# Altium schematic sheet IO.SchDoc
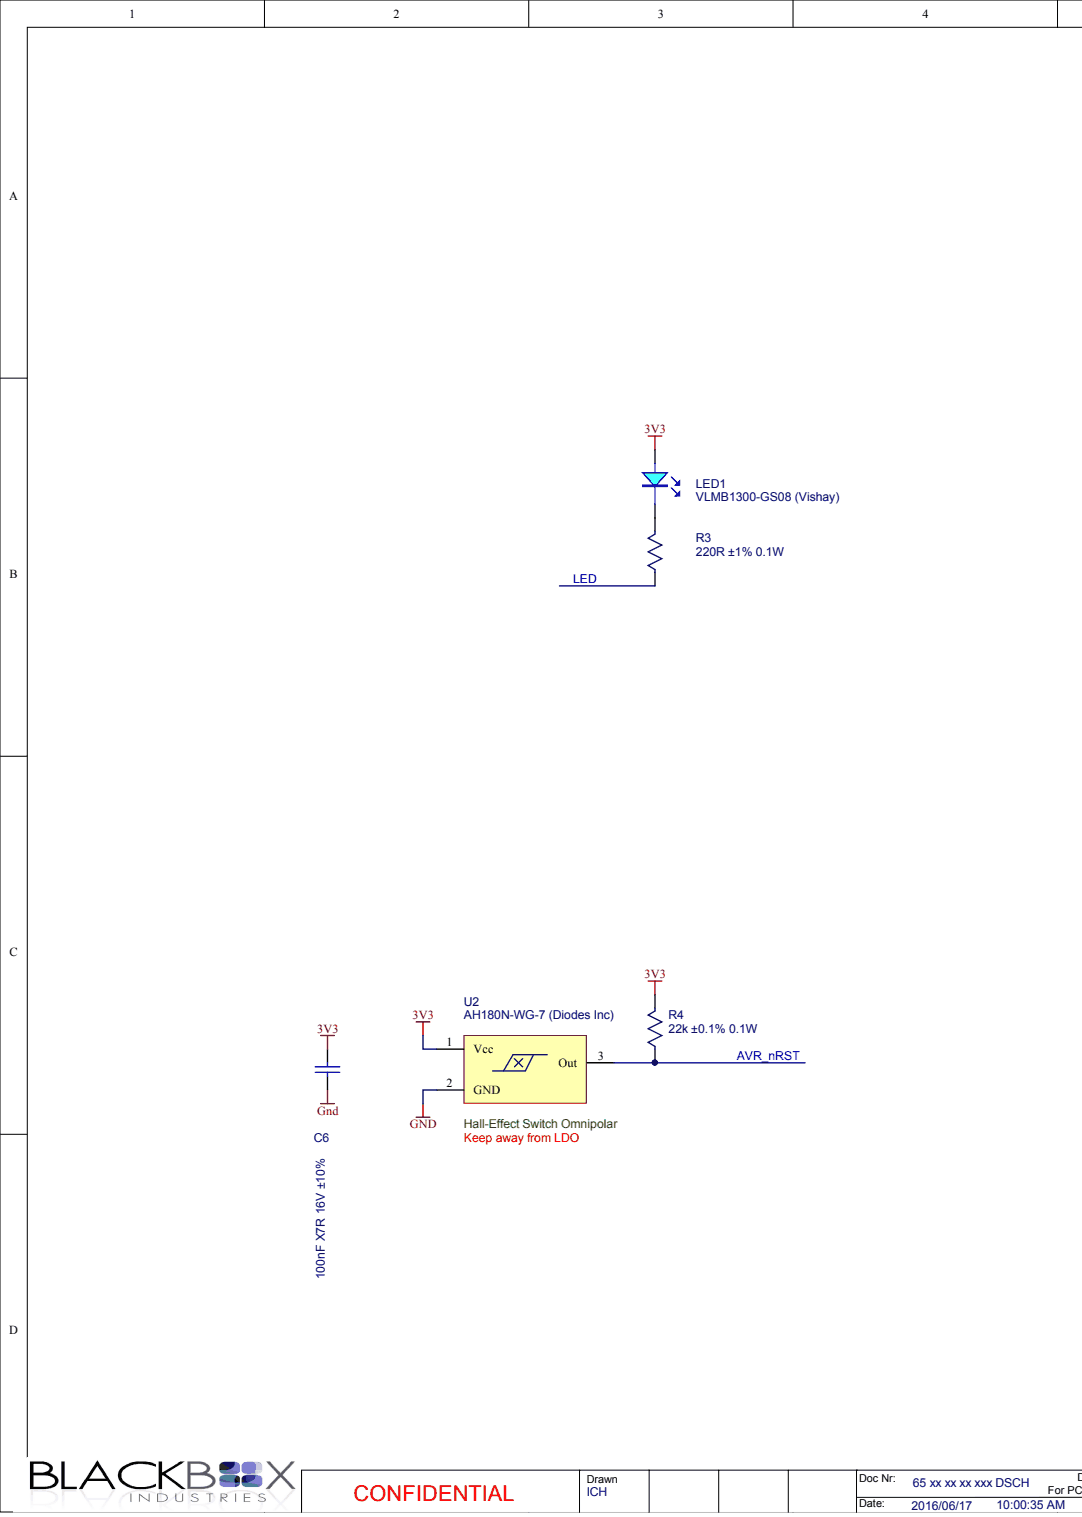
[diagram p0: IO.SchDoc, left]
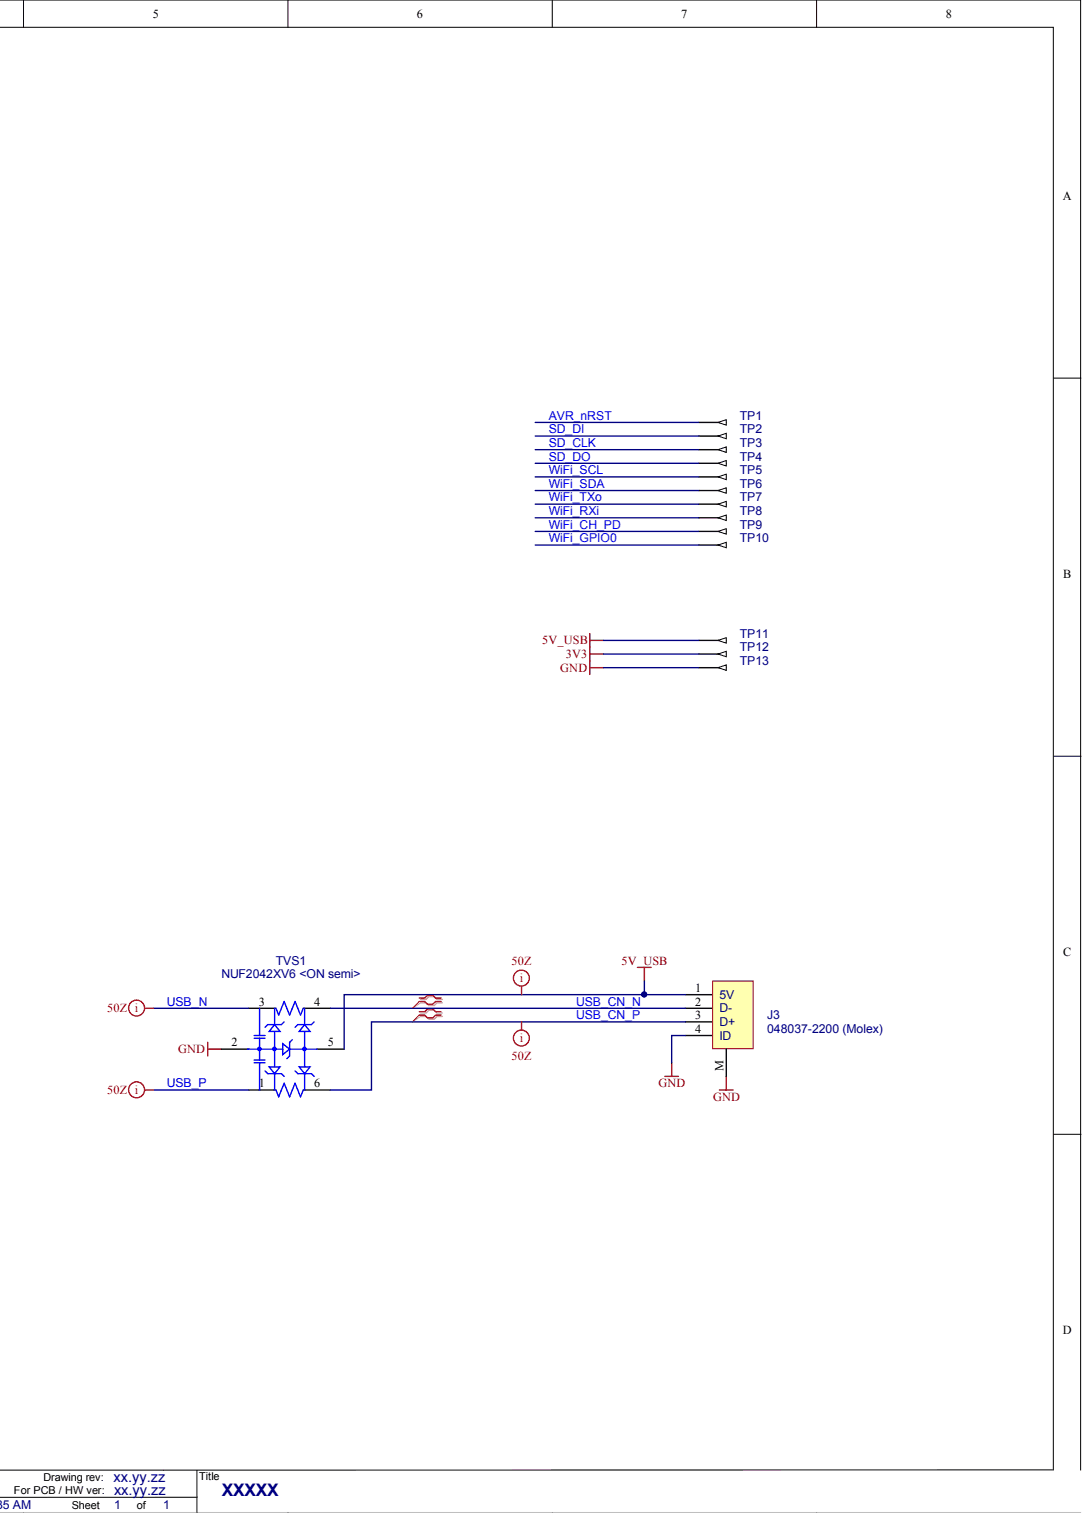
[diagram p1: IO.SchDoc, right]

## Parts
C6  100nF X7R 16V ±10%  [0603_CAP]
J3  048037-2200 (Molex)  [48037-2200 (MOLEX]
LED1  VLMB1300-GS08 (Vishay)  [0603_AC]
R3  220R ±1% 0.1W  [0603_RES]
R4  22k ±0.1% 0.1W  [0603_RES]
TP1  Meas  [TP_1.2mm_Dia]  (value not drawn)  (no BOM)
TP2  Meas  [TP_1.2mm_Dia]  (value not drawn)  (no BOM)
TP3  Meas  [TP_1.2mm_Dia]  (value not drawn)  (no BOM)
TP4  Meas  [TP_1.2mm_Dia]  (value not drawn)  (no BOM)
TP5  Meas  [TP_1.2mm_Dia]  (value not drawn)  (no BOM)
TP6  Meas  [TP_1.2mm_Dia]  (value not drawn)  (no BOM)
TP7  Meas  [TP_1.2mm_Dia]  (value not drawn)  (no BOM)
TP8  Meas  [TP_1.2mm_Dia]  (value not drawn)  (no BOM)
TP9  Meas  [TP_1.2mm_Dia]  (value not drawn)  (no BOM)
TP10  Meas  [TP_1.2mm_Dia]  (value not drawn)  (no BOM)
TP11  Meas  [TP_1.2mm_Dia]  (value not drawn)  (no BOM)
TP12  Meas  [TP_1.2mm_Dia]  (value not drawn)  (no BOM)
TP13  Meas  [TP_1.2mm_Dia]  (value not drawn)  (no BOM)
TVS1  NUF2042XV6 <ON semi>  [SOT666]
U2  AH180N-WG-7 (Diodes Inc)  [SOT-23-123]

## Nets
3V3: C6.1 LED1.A R4.2 TP12.1 U2.1
5V_USB: J3.1(5V) TP11.1 TVS1.5
GND: C6.2 J3.4(ID) J3.M TP13.1 TVS1.2 U2.2
AVR_NRST: R4.1 TP1.1 U2.3
LED: R3.1
SD_CLK: TP3.1
SD_DI: TP2.1
SD_DO: TP4.1
USB_CN_N: J3.2(D-) TVS1.4
USB_CN_P: J3.3(D+) TVS1.6
USB_N: TVS1.3
USB_P: TVS1.1
WIFI_CH_PD: TP9.1
WIFI_GPIO0: TP10.1
WIFI_RXI: TP8.1
WIFI_SCL: TP5.1
WIFI_SDA: TP6.1
WIFI_TXO: TP7.1
NetLED1_C: LED1.C R3.2

## Library data of the parts
C6 = 100nF X7R 16V ±10%: Capacitor, ceramic; Component Kind: Standard; descr: Capacitor, ceramic; Library Name: OneCell passives.IntLib; Supplier 1: Digi-Key; Supplier Part Number 1: 490-1532-2-ND
J3 = 048037-2200 (Molex): USB A Plug SMD; Component Kind: Standard; descr: USB A Plug SMD; Supplier 1: Digi-Key; Supplier Part Number 1: WM3983CT-ND; Library Name: Onecell Ig.IntLib
LED1 = VLMB1300-GS08 (Vishay): LED 0603 Blue clear 104mcd @ 20mA 130° Vf3.3; Component Kind: Standard; descr: LED 0603 Blue clear 104mcd @ 20mA 130° Vf3.3; Supplier 1: Digi-Key; Supplier Part Number 1: VLMB1300-GS08CT-ND; Library Name: Onecell Ig.IntLib
R3 = 220R ±1% 0.1W: resistor, thickfilm; Component Kind: Standard; descr: resistor, thickfilm; Library Name: OneCell passives.IntLib; Supplier 1: Digi-Key; Supplier Part Number 1: 311-220HRTR-ND
R4 = 22k ±0.1% 0.1W: Resistor, thin film; Component Kind: Standard; descr: Resistor, thin film; Supplier 1: Digi-Key; Supplier Part Number 1: P22KDBCT-ND; Library Name: Onecell Ig.IntLib
TP1-TP13 = Test Point (1.2mm): Testpad; descr: Testpad; Library Name: Onecell Ig.IntLib
TVS1 = NUF2042XV6 <ON semi>: USB ESD protection, EMI filter and termination; Component Kind: Standard; descr: USB ESD protection, EMI filter and termination; Library Name: OneCell.IntLib; Supplier 1: Digi-Key; Supplier Part Number 1: NUF2042XV6T1GOSTR-ND
U2 = AH180N-WG-7 (Diodes Inc): Hall-Effect Switch Omnipolar; Component Kind: Standard; descr: Hall-Effect Switch Omnipolar; Supplier 1: Digi-Key; Supplier Part Number 1: AH180N-WG-7DICT-ND; Library Name: Onecell Ig.IntLib
